# Altium schematic sheet Control_Schem.SchDoc(Control_Schem) (continued)
## Library data of the parts (continued)
D1-D4 = LED_Red: Radial T1 3/4 Infrared LED Infrared LED, 5mm, 1-Element, 950nm; Manufacturer: Osram; Fall Time: 12ns; Forward Current: 100mA; Forward Voltage: 1.7V; Lens Style: Circular, Dome; Max Power Dissipation: 180mW; Number of Circuits: 1; Output Power: 55mW; Power Dissipation: 180W; Reverse Voltage: 5V; Shape: Round; Touchscreen: Infrared (IR); Viewing Angle: 10°; Case/Package: Radial; Category: LEDs; China RoHS: Compliant; Component Kind: Standard; ComponentLink1Description: https://datasheet.octopart.com:443/Q65110A8095-Osram-Opto-datasheet-182349644.pdf?src-supplier=IHS; ComponentLink1URL: https://datasheet.octopart.com:443/Q65110A8095-Osram-Opto-datasheet-182349644.pdf?src-supplier=IHS; ComponentLink2Description: https://datasheet.octopart.com:443/SFH-4545-Osram-Opto-datasheet-142374590.pdf?src-supplier=Osram+Opto+SCT; ComponentLink2URL: https://datasheet.octopart.com:443/SFH-4545-Osram-Opto-datasheet-142374590.pdf?src-supplier=Osram+Opto+SCT; ComponentLink3Description: https://datasheet.octopart.com:443/SFH-4545-Osram-datasheet-68365660.pdf?src-supplier=TME; ComponentLink3URL: https://datasheet.octopart.com:443/SFH-4545-Osram-datasheet-68365660.pdf?src-supplier=TME; ComponentLink4Description: https://datasheet.octopart.com:443/Q65110A8095-Osram-datasheet-13703669.pdf?src-supplier=_legacy+Avnet; ComponentLink4URL: https://datasheet.octopart.com:443/Q65110A8095-Osram-datasheet-13703669.pdf?src-supplier=_legacy+Avnet; ComponentLink5Description: https://datasheet.octopart.com:443/SFH-4545-Osram-Sylvania-datasheet-17020525.pdf?src-supplier=Factory+Futures; ComponentLink5URL: https://datasheet.octopart.com:443/SFH-4545-Osram-Sylvania-datasheet-17020525.pdf?src-supplier=Factory+Futures; Contact Plating: Tin; Depth: 5.7mm; Harmonized Tariff Schedule (HTS) Code: 8541.40.20.00; Height: 7.9mm; Introduction Date: 2009-09-08; Length: 5.5mm; Library Name: Standard.SchLib; Lifecycle Status: Production; Manufacturer Part Number: SFH 4545; Max Operating Temperature: 100°C; Min Operating Temperature: -40°C; Mounting Technology: Through Hole; Number of Elements: 1; Number of LEDs: 1; Orientation: Top View; Packaging: Tape & Reel; Peak Wavelength: 950nm; Pins: 2; Power Rating: 180mW; Pricing: 1000=0.2268, 2000=0.1849, 3000=0.1831, 4000=0.1814 (USD); Radiation Hardening: No; REACH SVHC: Yes; Reverse Voltage (DC): 5V; Rise Time: 12ns; RoHS: Compliant; Schedule B: 8541402000, 8541402000|8541402000|8541402000|8541402000|8541402000; Stock: 100000; Supplier 1: Rutronik; Supplier Part Number 1: IR5241; Test Current: 100mA; Width: 5.5mm
D5 = LED_White: White 1.6 x 0.8 x 0.55 mm 130° Tinted Yellow 180 mcd 3 V LED SMT; Manufacturer: Diodes Inc.; Manufacturer Package Identifier: LTW-C191DS5; Forward Current: 20mA; Forward Voltage: 3.15V; Lens Style: Colored, Rectangular, Tinted; Luminous Intensity: 125.5mcd; Number of Circuits: 1; Power Dissipation: 72mW; Reverse Voltage: 5V; Shape: Rectangular; Test Current: 5mA; Case/Package: 0603; Category: LEDs; China RoHS: Compliant; Color: White; Component Kind: Standard; ComponentLink1Description: https://sigma.octopart.com:443/141906765/technical_drawing/Lite-On-LTW-C191DS5.pdf?src-supplier=IHS; ComponentLink1URL: https://sigma.octopart.com:443/141906765/technical_drawing/Lite-On-LTW-C191DS5.pdf?src-supplier=IHS; ComponentLink2Description: https://datasheet.octopart.com:443/LTW-C191DS5-Lite-On-datasheet-138896769.pdf?src-supplier=Upverter; ComponentLink2URL: https://datasheet.octopart.com:443/LTW-C191DS5-Lite-On-datasheet-138896769.pdf?src-supplier=Upverter; ComponentLink3Description: https://datasheet.octopart.com:443/LTW-C191DS5-Lite-On-datasheet-26684820.pdf?src-supplier=TME; ComponentLink3URL: https://datasheet.octopart.com:443/LTW-C191DS5-Lite-On-datasheet-26684820.pdf?src-supplier=TME; ComponentLink4Description: https://datasheet.octopart.com:443/LTW-C191DS5-Lite-On-datasheet-165573808.pdf?src-supplier=TME; ComponentLink4URL: https://datasheet.octopart.com:443/LTW-C191DS5-Lite-On-datasheet-165573808.pdf?src-supplier=TME; ComponentLink5Description: https://datasheet.octopart.com:443/LTW-C191DS5-Lite-On-datasheet-86397359.pdf?src-supplier=ODG+(Origin+Data+Global); ComponentLink5URL: https://datasheet.octopart.com:443/LTW-C191DS5-Lite-On-datasheet-86397359.pdf?src-supplier=ODG+(Origin+Data+Global); ComponentLink6Description: https://datasheet.octopart.com:443/LTW-C191DS5-Lite-On-datasheet-13698150.pdf?src-supplier=_legacy+Avnet; ComponentLink6URL: https://datasheet.octopart.com:443/LTW-C191DS5-Lite-On-datasheet-13698150.pdf?src-supplier=_legacy+Avnet; ComponentLink7Description: https://datasheet.octopart.com:443/LTW-C191DS5-Lite-On-datasheet-12531532.pdf?src-supplier=Future+Electronics; ComponentLink7URL: https://datasheet.octopart.com:443/LTW-C191DS5-Lite-On-datasheet-12531532.pdf?src-supplier=Future+Electronics; Depth: 800µm; Harmonized Tariff Schedule (HTS) Code: 8541.40.20.00; Height: 550µm; Illumination Color: White; Introduction Date: 2006-05-17; Lead Free: Lead Free; Length: 1.6mm; Lens Color: Yellow; Library Name: Standard.SchLib; Lifecycle Status: Production; Manufacturer Part Number: LTW-C191DS5; Max Operating Temperature: 85°C; Min Operating Temperature: -30°C; Mounting Technology: Surface Mount; Number of Elements: 1; Number of LEDs: 1; Number of Terminals: 2; Pins: 2; Pricing: 5000=0.10228, 10000=0.09997, 20000=0.09766, 40000=0.09536, 80000=0.09305 (USD); Radiation Hardening: No; REACH SVHC: Yes; RoHS: Compliant; Stock: 35000; Supplier 1: Avnet; Supplier Part Number 1: LTW-C191DS5; Terminal Pitch: 1.5mm; Viewing Angle: 130°; Width: 800µm
D6 = LED_Blue: LED blue 0603 wavelength 465~475nm light intensity 15mcd Front Mounting; Manufacturer: Diodes Inc.; Manufacturer Part Number: LTST-C193TBKT-5A; Dominant Wavelength: 475nm; Forward Current: 20mA; Forward Voltage: 2.8V; Lens Color: Clear, Colorless; Lens Style: Clear, Colorless, Diffused, Rectangular; Lens Transparency: Clear; Number of Circuits: 1; Peak Wavelength: 468nm; Power Dissipation: 76mW; Reverse Voltage: 5V; Shape: Rectangular; Test Current: 5mA; Viewing Angle: 130°; Wavelength: 470nm; Case Code (Imperial): 0603; Case Code (Metric): 1608; Case/Package: 0603; Category: LEDs; China RoHS: Compliant; Color: Blue; Component Kind: Standard; ComponentLink1Description: https://datasheet.octopart.com:443/LTST-C193TBKT-5A-Lite-On-datasheet-26684754.pdf?src-supplier=IHS; ComponentLink1URL: https://datasheet.octopart.com:443/LTST-C193TBKT-5A-Lite-On-datasheet-26684754.pdf?src-supplier=IHS; ComponentLink2Description: https://datasheet.octopart.com:443/LTST-C193TBKT-5A-Lite-On-datasheet-12541985.pdf?src-supplier=Upverter; ComponentLink2URL: https://datasheet.octopart.com:443/LTST-C193TBKT-5A-Lite-On-datasheet-12541985.pdf?src-supplier=Upverter; ComponentLink3Description: https://datasheet.octopart.com:443/LTST-C193TBKT-5A-Lite-On-datasheet-165573808.pdf?src-supplier=TME; ComponentLink3URL: https://datasheet.octopart.com:443/LTST-C193TBKT-5A-Lite-On-datasheet-165573808.pdf?src-supplier=TME; ComponentLink4Description: https://datasheet.octopart.com:443/LTST-C193TBKT-5A-Lite-On-datasheet-14174967.pdf?src-supplier=iiiC; ComponentLink4URL: https://datasheet.octopart.com:443/LTST-C193TBKT-5A-Lite-On-datasheet-14174967.pdf?src-supplier=iiiC; Contact Plating: Tin; Depth: 800µm; Height: 350µm; Illumination Color: Blue; Lead Free: Lead Free; Length: 1.6mm; Library Name: Standard.SchLib; Lifecycle Status: Production; Luminous Intensity: 15mcd; Max Operating Temperature: 80°C; Min Operating Temperature: -20°C; Mounting Technology: Surface Mount; Number of Elements: 1; Number of LEDs: 1; Number of Terminals: 2; Pins: 2; Pricing: 5000=0.04509, 10000=0.04407, 20000=0.04305, 40000=0.04204, 80000=0.04102 (USD); Radiation Hardening: No; REACH SVHC: Yes; RoHS: Compliant; Schedule B: 8541402000, 8541402000|8541402000|8541402000|8541402000|8541402000; Stock: 200000; Supplier 1: Avnet; Supplier Part Number 1: LTST-C193TBKT-5A; Terminal Pitch: 1.5mm; Width: 800µm
D7 = LED_Red: Single-color LEDs; LITEON; LTST-C193KRKT-5A; Red; 130 °; 2.3 V; 0603; Manufacturer: Diodes Inc.; Dominant Wavelength: 631nm; Forward Current: 20mA; Forward Voltage: 2.3V; Lens Color: Clear, Colorless; Lens Style: Clear, Colorless, Diffused, Rectangular; Lens Transparency: Clear; Luminous Intensity: 26.05mcd; Number of Circuits: 1; Peak Wavelength: 639nm; Power Dissipation: 50mW; Reverse Voltage: 5V; Shape: Rectangular; Test Current: 5mA; Viewing Angle: 130°; Case Code (Imperial): 0603; Case Code (Metric): 1608; Case/Package: 0603; Category: LEDs; China RoHS: Compliant; Color: Red; Component Kind: Standard; ComponentLink1Description: https://datasheet.octopart.com:443/LTST-C193KRKT-5A-Lite-On-datasheet-141422095.pdf?src-supplier=ODG+(Origin+Data+Global); ComponentLink1URL: https://datasheet.octopart.com:443/LTST-C193KRKT-5A-Lite-On-datasheet-141422095.pdf?src-supplier=ODG+(Origin+Data+Global); ComponentLink2Description: https://datasheet.octopart.com:443/LTST-C193KRKT-5A-Lite-On-datasheet-86397331.pdf?src-supplier=Upverter; ComponentLink2URL: https://datasheet.octopart.com:443/LTST-C193KRKT-5A-Lite-On-datasheet-86397331.pdf?src-supplier=Upverter; ComponentLink3Description: https://datasheet.octopart.com:443/LTST-C193KRKT-5A-Lite-On-datasheet-180605208.pdf?src-supplier=IHS; ComponentLink3URL: https://datasheet.octopart.com:443/LTST-C193KRKT-5A-Lite-On-datasheet-180605208.pdf?src-supplier=IHS; ComponentLink4Description: https://datasheet.octopart.com:443/LTST-C193KRKT-5A-Lite-On-datasheet-26684753.pdf?src-supplier=TME; ComponentLink4URL: https://datasheet.octopart.com:443/LTST-C193KRKT-5A-Lite-On-datasheet-26684753.pdf?src-supplier=TME; ComponentLink5Description: https://datasheet.octopart.com:443/LTST-C193KRKT-5A-Lite-On-datasheet-165573808.pdf?src-supplier=TME; ComponentLink5URL: https://datasheet.octopart.com:443/LTST-C193KRKT-5A-Lite-On-datasheet-165573808.pdf?src-supplier=TME; ComponentLink6Description: https://datasheet.octopart.com:443/LTST-C193KRKT-5A-Lite-On-datasheet-13688521.pdf?src-supplier=_legacy+Avnet; ComponentLink6URL: https://datasheet.octopart.com:443/LTST-C193KRKT-5A-Lite-On-datasheet-13688521.pdf?src-supplier=_legacy+Avnet; ComponentLink7Description: https://datasheet.octopart.com:443/LTST-C193KRKT-5A-Lite-On-datasheet-137080926.pdf?src-supplier=X-ON; ComponentLink7URL: https://datasheet.octopart.com:443/LTST-C193KRKT-5A-Lite-On-datasheet-137080926.pdf?src-supplier=X-ON; ComponentLink8Description: https://datasheet.octopart.com:443/LTST-C193KRKT-5A-Lite-On-datasheet-11563339.pdf?src-supplier=Arrow+Electronics; ComponentLink8URL: https://datasheet.octopart.com:443/LTST-C193KRKT-5A-Lite-On-datasheet-11563339.pdf?src-supplier=Arrow+Electronics; ComponentLink9Description: https://datasheet.octopart.com:443/LTST-C193KRKT-5A-Lite-On-datasheet-14174970.pdf?src-supplier=iiiC; ComponentLink9URL: https://datasheet.octopart.com:443/LTST-C193KRKT-5A-Lite-On-datasheet-14174970.pdf?src-supplier=iiiC; ComponentLink10Description: https://datasheet.octopart.com:443/LTST-C193KRKT-5A-Lite-On-datasheet-25360257.pdf?src-supplier=Future+Electronics; ComponentLink10URL: https://datasheet.octopart.com:443/LTST-C193KRKT-5A-Lite-On-datasheet-25360257.pdf?src-supplier=Future+Electronics; Country of Origin: Mainland China, Thailand; Depth: 800µm; Export Control Classification Number (ECCN) Code: EAR99; Harmonized Tariff Schedule (HTS) Code: 8541.40.20.00; Height: 350µm; Illumination Color: Red; Introduction Date: 2008-09-01; Lead Free: Lead Free; Length: 1.6mm; Library Name: Standard.SchLib; Lifecycle Status: Production; Manufacturer Part Number: LTST-C193KRKT-5A; Max Operating Temperature: 85°C; Min Operating Temperature: -30°C; Mounting Technology: Surface Mount; Number of Elements: 1; Number of LEDs: 1; Number of Terminals: 2; Pins: 2; Pricing: 5000=0.03977, 10000=0.03887, 20000=0.03797, 40000=0.03708, 80000=0.03618 (USD); Radiation Hardening: No; REACH SVHC: Yes; RoHS: Compliant; Schedule B: 8541402000, 8541402000|8541402000|8541402000|8541402000|8541402000; Stock: 250000; Supplier 1: Avnet; Supplier Part Number 1: LTST-C193KRKT-5A; Terminal Pitch: 1.5mm; Wavelength: 631nm; Width: 800µm
D8 D9 = Zenner: ESD9X5.0ST5G Series 6.2 V 65 pF TVS Diode for ESD Protection - SOD-923; Manufacturer: onsemi; Manufacturer Lifecycle Status: ACTIVE; Breakdown Voltage: 6.2V; Clamping Voltage: 12.3V; Direction: Unidirectional; Element Configuration: Single; ESD Protection: Yes; Forward Voltage: 1.1V; Leakage Current: 1µA; Max Junction Temperature (Tj): 150°C; Max Power Dissipation: 150mW; Max Surge Current: 8.7A; Number of Channels: 1; Operating Supply Voltage: 5V; Peak Pulse Power: 107W; Power Line Protection: No; Test Current: 1mA; Case/Package: SOD-923-2; Category: TVS Diodes; China RoHS: Compliant; Component Kind: Standard; ComponentLink1Description: https://datasheet.octopart.com:443/ESD9X5.0ST5G-ON-Semiconductor-datasheet-8330357.pdf?src-supplier=Upverter; ComponentLink1URL: https://datasheet.octopart.com:443/ESD9X5.0ST5G-ON-Semiconductor-datasheet-8330357.pdf?src-supplier=Upverter; ComponentLink2Description: https://datasheet.octopart.com:443/ESD9X5.0ST5G-onsemi-datasheet-168568609.pdf?src-supplier=Upverter; ComponentLink2URL: https://datasheet.octopart.com:443/ESD9X5.0ST5G-onsemi-datasheet-168568609.pdf?src-supplier=Upverter; ComponentLink3Description: https://datasheet.octopart.com:443/ESD9X5.0ST5G-ON-Semiconductor-datasheet-139009868.pdf?src-supplier=Electro+Sonic; ComponentLink3URL: https://datasheet.octopart.com:443/ESD9X5.0ST5G-ON-Semiconductor-datasheet-139009868.pdf?src-supplier=Electro+Sonic; ComponentLink4Description: https://datasheet.octopart.com:443/ESD9X5.0ST5G-onsemi-datasheet-180958921.pdf?src-supplier=IHS; ComponentLink4URL: https://datasheet.octopart.com:443/ESD9X5.0ST5G-onsemi-datasheet-180958921.pdf?src-supplier=IHS; ComponentLink5Description: https://datasheet.octopart.com:443/ESD9X5.0ST5G-ON-Semiconductor-datasheet-12513463.pdf?src-supplier=Newark; ComponentLink5URL: https://datasheet.octopart.com:443/ESD9X5.0ST5G-ON-Semiconductor-datasheet-12513463.pdf?src-supplier=Newark; ComponentLink6Description: https://datasheet.octopart.com:443/ESD9X5.0ST5G-onsemi-datasheet-189128587.pdf?src-supplier=Master+Electronics; ComponentLink6URL: https://datasheet.octopart.com:443/ESD9X5.0ST5G-onsemi-datasheet-189128587.pdf?src-supplier=Master+Electronics; ComponentLink7Description: https://datasheet.octopart.com:443/ESD9X5.0ST5G-onsemi-datasheet-164667579.pdf?src-supplier=Newchip+Electronics+Limited; ComponentLink7URL: https://datasheet.octopart.com:443/ESD9X5.0ST5G-onsemi-datasheet-164667579.pdf?src-supplier=Newchip+Electronics+Limited; ComponentLink8Description: https://datasheet.octopart.com:443/ESD9X5.0ST5G-ON-Semiconductor-datasheet-14047635.pdf?src-supplier=iiiC; ComponentLink8URL: https://datasheet.octopart.com:443/ESD9X5.0ST5G-ON-Semiconductor-datasheet-14047635.pdf?src-supplier=iiiC; ComponentLink9Description: http://sigma.octopart.com:80/15540786/rohs_certificate/ON-Semiconductor-ESD9X5.0ST5G.pdf?src-supplier=Compliance+Statements; ComponentLink9URL: http://sigma.octopart.com:80/15540786/rohs_certificate/ON-Semiconductor-ESD9X5.0ST5G.pdf?src-supplier=Compliance+Statements; ComponentLink10Description: http://sigma.octopart.com:80/15676178/materials_sheet/ON-Semiconductor-ESD9X5.0ST5G.csv?src-supplier=Compliance+Statements; ComponentLink10URL: http://sigma.octopart.com:80/15676178/materials_sheet/ON-Semiconductor-ESD9X5.0ST5G.csv?src-supplier=Compliance+Statements; ComponentLink11Description: http://sigma.octopart.com:80/150607609/rohs_statement/ON-Semiconductor-ESD9X5.0ST5G.pdf?src-supplier=Compliance+Statements; ComponentLink11URL: http://sigma.octopart.com:80/150607609/rohs_statement/ON-Semiconductor-ESD9X5.0ST5G.pdf?src-supplier=Compliance+Statements; ComponentLink12Description: http://sigma.octopart.com:80/reach_statement/ON-Semiconductor-company-79.pdf?src-supplier=Compliance+Statements; ComponentLink12URL: http://sigma.octopart.com:80/reach_statement/ON-Semiconductor-company-79.pdf?src-supplier=Compliance+Statements; ComponentLink13Description: https://octopart.com:443/opatz8j6/c1?t=U4xq248CjZk49aqVlr5k0EZ1S20O7hXZC5x3rHWSH-sYxZ_igJwI8JXMjxjum5nk7b2LPFvSUdjhtWeLCCyF45HKJ3gQrOw2ICCLdwIt4F_CeAv9k9h4bPg01xsOqGsl4_WPHk2-sHZisKbPVHvESszEH42MI_EGcnWZn37s8EDrb9R9sRHl5QrF73OS571ory3wTq49jEdwCvmsvOyGlnMZqdznSgUnAulqt8UeVTtCWi1h0LjaV3_jenB72zXObhJcrUkKGX87J72uvMIaqlf2gdrzqerpU4qgDLbtRMl999sH-387FE5OvjKwPt4qFBwoXQia9kWdxdoPHguyJMxxDQ6CZJ78I1Jz7wf_&src-supplier=Compliance+Statements; ComponentLink13URL: https://octopart.com:443/opatz8j6/c1?t=U4xq248CjZk49aqVlr5k0EZ1S20O7hXZC5x3rHWSH-sYxZ_igJwI8JXMjxjum5nk7b2LPFvSUdjhtWeLCCyF45HKJ3gQrOw2ICCLdwIt4F_CeAv9k9h4bPg01xsOqGsl4_WPHk2-sHZisKbPVHvESszEH42MI_EGcnWZn37s8EDrb9R9sRHl5QrF73OS571ory3wTq49jEdwCvmsvOyGlnMZqdznSgUnAulqt8UeVTtCWi1h0LjaV3_jenB72zXObhJcrUkKGX87J72uvMIaqlf2gdrzqerpU4qgDLbtRMl999sH-387FE5OvjKwPt4qFBwoXQia9kWdxdoPHguyJMxxDQ6CZJ78I1Jz7wf_&src-supplier=Compliance+Statements; ComponentLink14Description: https://octopart.com:443/opatz8j6/c1?t=3pWjA3sury-vXDZ6jn5EtAlCMoxYtX1AYxU0u-L05MRKzzY3i90hWYZqBJ7nD0FpcxQU6NVvWSfrj8spDvkDVcv6hBGs2FKejoF387FKwRHUXxrKuAp20zxQf1xviP5DOSGH0oDp6nciOnSgABqDgBRfE1IdXVb1HgMibrXHg5ILIw0i4bTNvinhI9nbqtNHG7c2z1LCxAmzkrm1UggRYQnaU9WfKM8lDyf7V15dE3D-xK99Oqq1XK1trMaZ5tCK2HDTe7-XsgP0FTthRAXuAn3xjXwE5sHZ4Tfbg-hc08R_QhUItco4PPzxqp_Ni38_sf5Aw8nlbFeh5fp6Pc1W3BfPLWy2YwzTcFmoPFUupg&src-supplier=Compliance+Statements; ComponentLink14URL: https://octopart.com:443/opatz8j6/c1?t=3pWjA3sury-vXDZ6jn5EtAlCMoxYtX1AYxU0u-L05MRKzzY3i90hWYZqBJ7nD0FpcxQU6NVvWSfrj8spDvkDVcv6hBGs2FKejoF387FKwRHUXxrKuAp20zxQf1xviP5DOSGH0oDp6nciOnSgABqDgBRfE1IdXVb1HgMibrXHg5ILIw0i4bTNvinhI9nbqtNHG7c2z1LCxAmzkrm1UggRYQnaU9WfKM8lDyf7V15dE3D-xK99Oqq1XK1trMaZ5tCK2HDTe7-XsgP0FTthRAXuAn3xjXwE5sHZ4Tfbg-hc08R_QhUItco4PPzxqp_Ni38_sf5Aw8nlbFeh5fp6Pc1W3BfPLWy2YwzTcFmoPFUupg&src-supplier=Compliance+Statements; Composition: Zener; Contact Plating: Tin; Depth: 600µm; Halogen Free: Halogen Free; Height: 400µm; Lead Free: Lead Free; Length: 800µm; Library Name: Standard.SchLib; Lifecycle Status: Production; Manufacturer Part Number: ESD9X5.0ST5G; Max Breakdown Voltage: 6.2V; Max Operating Temperature: 150°C; Max Reverse Leakage Current: 1µA; Min Breakdown Voltage: 6.2V; Min Operating Temperature: -55°C; Number of Circuits: 1; Number of Elements: 1; Number of Lines: 1; Number of Terminals: 2; Number of Unidirectional Channels: 1; Packaging: Tape and Reel; Peak Pulse Current: 8.7A; Peak Reverse Current: 1µA; Pins: 2; Polarity: Unidirectional; Pricing: 8000=0.0268, 24000=0.0262, 32000=0.0261, 80000=0.0255, 120000=0.025 (USD); Radiation Hardening: No; REACH SVHC: Yes; Reverse Breakdown Voltage: 6.2V; Reverse Standoff Voltage: 5V; RoHS: Compliant; Schedule B: 8536300000, 8536300000|8536300000|8536300000|8536300000|8536300000|8536300000|8536300000|8536300000|8536300000|8536300000|8536300000|8536300000|8536300000|8536300000|8536300000|8536300000|8536300000|8536300000|8536300000|8536300000|8536300000|8536300000|8536300000|85; Stock: 200000; Supplier 1: Future Electronics; Supplier Part Number 1: ESD9X5.0ST5G; Termination: SMD/SMT; Value: 65pF; Width: 650µm; Working Voltage: 5V
J2 J3 = 6POS: Connector Header, SR Series, 6 Position, 1.00mm, 50V, Surface Mount, Tin, Natural; Manufacturer: JST; Manufacturer Part Number: BM06B-SRSS-TB(LF)(SN); Connector Type: Male Pin; Contact Resistance: 40mΩ; Current Rating: 1A; Dielectric Material: Polyamide; Gender: Male; Insulation Resistance: 100MΩ; Number of Contacts: 6; Pitch: 1mm; Shape: Straight; Category: Headers and Wire Housings; China RoHS: Compliant; Color: Natural; Component Kind: Standard; ComponentLink1Description: https://datasheet.octopart.com:443/BM06B-SRSS-TB%28LF%29%28SN%29-JST-datasheet-120967.pdf?src-supplier=Upverter; ComponentLink1URL: https://datasheet.octopart.com:443/BM06B-SRSS-TB%28LF%29%28SN%29-JST-datasheet-120967.pdf?src-supplier=Upverter; ComponentLink2Description: https://datasheet.octopart.com:443/BM06B-SRSS-TB%28LF%29%28SN%29-JST-datasheet-152738602.pdf?src-supplier=Upverter; ComponentLink2URL: https://datasheet.octopart.com:443/BM06B-SRSS-TB%28LF%29%28SN%29-JST-datasheet-152738602.pdf?src-supplier=Upverter; ComponentLink3Description: https://datasheet.octopart.com:443/BM06B-SRSS-TB%28LF%29%28SN%29-JST-datasheet-12489618.pdf?src-supplier=Component+Distributors+Inc.; ComponentLink3URL: https://datasheet.octopart.com:443/BM06B-SRSS-TB%28LF%29%28SN%29-JST-datasheet-12489618.pdf?src-supplier=Component+Distributors+Inc.; ComponentLink4Description: https://datasheet.octopart.com:443/BM06B-SRSS-TB%28LF%29%28SN%29-JST-datasheet-65849987.pdf?src-supplier=element14+APAC; ComponentLink4URL: https://datasheet.octopart.com:443/BM06B-SRSS-TB%28LF%29%28SN%29-JST-datasheet-65849987.pdf?src-supplier=element14+APAC; ComponentLink5Description: https://datasheet.octopart.com:443/BM06B-SRSS-TB%28LF%29%28SN%29-JST-datasheet-12513377.pdf?src-supplier=Factory+Futures; ComponentLink5URL: https://datasheet.octopart.com:443/BM06B-SRSS-TB%28LF%29%28SN%29-JST-datasheet-12513377.pdf?src-supplier=Factory+Futures; ComponentLink6Description: https://datasheet.octopart.com:443/BM06B-SRSS-TB%28LF%29%28SN%29-JST-datasheet-5322443.pdf?src-supplier=Newark; ComponentLink6URL: https://datasheet.octopart.com:443/BM06B-SRSS-TB%28LF%29%28SN%29-JST-datasheet-5322443.pdf?src-supplier=Newark; ComponentLink7Description: https://datasheet.octopart.com:443/BM06B-SRSS-TB-%28LF%29%28SN%29-JST-datasheet-44103209.pdf?src-supplier=TME; ComponentLink7URL: https://datasheet.octopart.com:443/BM06B-SRSS-TB-%28LF%29%28SN%29-JST-datasheet-44103209.pdf?src-supplier=TME; ComponentLink8Description: https://datasheet.octopart.com:443/BM06B-SRSS-TB%28LF%29%28SN%29-JST-datasheet-8330955.pdf?src-supplier=Farnell; ComponentLink8URL: https://datasheet.octopart.com:443/BM06B-SRSS-TB%28LF%29%28SN%29-JST-datasheet-8330955.pdf?src-supplier=Farnell; Contact Material: Copper, Tin; Contact Plating: Tin; Country of Origin: Mainland China; Export Control Classification Number (ECCN) Code: EAR99; Flammability Rating: UL94 V-0; Harmonized Tariff Schedule (HTS) Code: 8536.69.40.40; Height: 4.25mm; Housing Color: Natural; Housing Material: Nylon; Introduction Date: 2009-02-11; Lead Free: Lead Free; Lead Pitch: 1mm; Length: 8mm; Library Name: Connectors.SchLib; Lifecycle Status: Production; Material: Polyamide; Max Operating Temperature: 85°C; Min Operating Temperature: -25°C; Mounting Technology: Surface Mount; Number of Poles: 6; Number of Positions: 6; Number of Rows: 1; Packaging: Cut Tape; Pins: 6; Pricing: 1500=0.35, 3000=0.345, 4500=0.34 (USD); REACH SVHC: Yes; RoHS: Compliant; Schedule B: 8536694040; Stock: 27000; Supplier 1: Future Electronics; Supplier Part Number 1: BM06B-SRSS-TB(LF)(SN); Terminal Pitch: 1mm; Termination: Solder; Voltage Rating: 50V; Voltage Rating (AC): 50V; Width: 2.9mm
J4 = 6POS: Connector Header, SR Series, 6 Position, 1.00mm, 50V, Surface Mount, Tin, Natural; Library Name: Connectors.SchLib
Q1 Q2 Q5 Q6 = NPN_PHOTOTRANSISTOR: TEFT4300 Series 925 nm ±60° 70 V 50 mA Silicon NPN Phototransistor - T-5; Manufacturer: Vishay; Collector Emitter Breakdown Voltage: 70V; Collector Emitter Saturation Voltage: 300mV; Dark Current: 200nA; Fall Time: 2.3µs; Lens Style: Domed; Max Collector Current: 50mA; Max Power Dissipation: 185mW; Number of Channels: 1; Peak Wavelength: 925nm; Power Consumption: 100mW; Shape: Round; Viewing Angle: 30°; Case/Package: T; Category: Photoelectric Sensors; Collector Emitter Voltage (VCEO): 70V; Component Kind: Standard; ComponentLink1Description: https://datasheet.octopart.com:443/TEFT4300-Vishay-datasheet-181475792.pdf?src-supplier=IHS; ComponentLink1URL: https://datasheet.octopart.com:443/TEFT4300-Vishay-datasheet-181475792.pdf?src-supplier=IHS; ComponentLink2Description: https://datasheet.octopart.com:443/TEFT4300-Vishay-datasheet-181483772.pdf?src-supplier=IHS; ComponentLink2URL: https://datasheet.octopart.com:443/TEFT4300-Vishay-datasheet-181483772.pdf?src-supplier=IHS; ComponentLink3Description: https://datasheet.octopart.com:443/TEFT4300-Vishay-datasheet-12551779.pdf?src-supplier=Farnell; ComponentLink3URL: https://datasheet.octopart.com:443/TEFT4300-Vishay-datasheet-12551779.pdf?src-supplier=Farnell; ComponentLink4Description: https://datasheet.octopart.com:443/TEFT4300-Vishay-datasheet-8540529.pdf?src-supplier=Farnell; ComponentLink4URL: https://datasheet.octopart.com:443/TEFT4300-Vishay-datasheet-8540529.pdf?src-supplier=Farnell; ComponentLink5Description: https://datasheet.octopart.com:443/TEFT4300-Vishay-datasheet-62319322.pdf?src-supplier=element14+APAC; ComponentLink5URL: https://datasheet.octopart.com:443/TEFT4300-Vishay-datasheet-62319322.pdf?src-supplier=element14+APAC; ComponentLink6Description: https://datasheet.octopart.com:443/TEFT4300-Vishay-Semiconductor-datasheet-41195801.pdf?src-supplier=element14+APAC; ComponentLink6URL: https://datasheet.octopart.com:443/TEFT4300-Vishay-Semiconductor-datasheet-41195801.pdf?src-supplier=element14+APAC; ComponentLink7Description: https://datasheet.octopart.com:443/TEFT4300..-Vishay-datasheet-78863672.pdf?src-supplier=element14+APAC; ComponentLink7URL: https://datasheet.octopart.com:443/TEFT4300..-Vishay-datasheet-78863672.pdf?src-supplier=element14+APAC; ComponentLink8Description: https://datasheet.octopart.com:443/TEFT4300-Vishay-datasheet-68328189.pdf?src-supplier=TME; ComponentLink8URL: https://datasheet.octopart.com:443/TEFT4300-Vishay-datasheet-68328189.pdf?src-supplier=TME; ComponentLink9Description: https://datasheet.octopart.com:443/TEFT4300-Vishay-datasheet-33014373.pdf?src-supplier=Newark; ComponentLink9URL: https://datasheet.octopart.com:443/TEFT4300-Vishay-datasheet-33014373.pdf?src-supplier=Newark; ComponentLink10Description: https://datasheet.octopart.com:443/TEFT4300-Vishay-datasheet-63338.pdf?src-supplier=Newark; ComponentLink10URL: https://datasheet.octopart.com:443/TEFT4300-Vishay-datasheet-63338.pdf?src-supplier=Newark; ComponentLink11Description: http://sigma.octopart.com:80/rohs_statement/Vishay-company-15.pdf?src-supplier=Compliance+Statements; ComponentLink11URL: http://sigma.octopart.com:80/rohs_statement/Vishay-company-15.pdf?src-supplier=Compliance+Statements; Harmonized Tariff Schedule (HTS) Code: 8541.40.70.80; Height: 4.5mm; Introduction Date: 1995-08-01; Lead Free: Lead Free; Length: 3.2mm; Library Name: Standard.SchLib; Lifecycle Status: Production; Manufacturer Part Number: TEFT4300; Max Operating Temperature: 100°C; Min Operating Temperature: -40°C; Mounting Technology: Through Hole; Number of Circuits: 1; Number of Elements: 1; Orientation: Top View; Pins: 2; Polarity: NPN; Power Dissipation: 100mW; Pricing: 5000=0.17962, 10000=0.17625, 20000=0.17407, 40000=0.17091, 80000=0.16588 (USD); Radiation Hardening: No; REACH SVHC: Yes; RoHS: Compliant; Schedule B: 8541407080, 8541407080|8541407080|8541407080|8541407080|8541407080; Stock: 5000; Supplier: Avnet; Supplier 1: Avnet; Supplier Part Number: TEFT4300; Supplier Part Number 1: TEFT4300; Wavelength: 925nm; Width: 3.9mm
Q3 Q4 Q7 Q8 = NMOS: Transistor MOSFET N-CH 60V 0.32A Automotive 3-Pin SC-70 T/R; Manufacturer: Nexperia; Manufacturer Lifecycle Status: RELEASED FOR SUPPLY; Continuous Drain Current (ID): 320mA; Drain to Source Resistance: 1.6Ω; Max Power Dissipation: 310mW; Number of Elements: 1; Category: MOSFETs; China RoHS: Compliant; Component Kind: Standard; ComponentLink1Description: https://datasheet.octopart.com:443/BSS138PW%2C115-Nexperia-datasheet-87581096.pdf?src-supplier=Newark; ComponentLink1URL: https://datasheet.octopart.com:443/BSS138PW%2C115-Nexperia-datasheet-87581096.pdf?src-supplier=Newark; ComponentLink2Description: https://datasheet.octopart.com:443/BSS138PW%2C115-Nexperia-datasheet-10542180.pdf?src-supplier=IHS; ComponentLink2URL: https://datasheet.octopart.com:443/BSS138PW%2C115-Nexperia-datasheet-10542180.pdf?src-supplier=IHS; ComponentLink3Description: https://datasheet.octopart.com:443/BSS138PW%2C115-Nexperia-datasheet-180923270.pdf?src-supplier=Farnell; ComponentLink3URL: https://datasheet.octopart.com:443/BSS138PW%2C115-Nexperia-datasheet-180923270.pdf?src-supplier=Farnell; ComponentLink4Description: http://sigma.octopart.com:80/reach_statement/NXP-Semiconductors-company-13.pdf?src-supplier=Compliance+Statements; ComponentLink4URL: http://sigma.octopart.com:80/reach_statement/NXP-Semiconductors-company-13.pdf?src-supplier=Compliance+Statements; ComponentLink5Description: http://sigma.octopart.com:80/rohs_statement/NXP-Semiconductors-company-12.pdf?src-supplier=Compliance+Statements; ComponentLink5URL: http://sigma.octopart.com:80/rohs_statement/NXP-Semiconductors-company-12.pdf?src-supplier=Compliance+Statements; Contact Plating: Tin; Country of Origin: Malaysia; Export Control Classification Number (ECCN) Code: EAR99; Introduction Date: 2010-11-11; Library Name: Standard.SchLib; Lifecycle Status: Production; Manufacturer Part Number: BSS138PW,115; Max Operating Temperature: 150°C; Min Breakdown Voltage: 60V; Number of Terminals: 3; Packaging: Tape & Reel; Pins: 3; Pricing: 5=0.35, 10=0.217, 25=0.189, 50=0.161, 100=0.133 (USD); Ratings: AEC-Q101; REACH SVHC: Yes; RoHS: Compliant; Schedule B: 8541210080, 8541210080|8541210080, 8541210080|8541210080|8541210080, 8541210080|8541210080|8541210080|8541210080; Stock: 868; Supplier: Newark; Supplier 1: Newark; Supplier Part Number: 58T1369; Supplier Part Number 1: BSS138PW,115
R1 R2 R14 R15 = RES: 100mW Thick Film Resistors 75V ±5% ±200ppm/℃ 3Ω 0603 Chip Resistor - Surface Mount ROHS; Manufacturer: Yageo Group; Manufacturer Part Number: RC0603JR-073RL; Temperature Coefficient: 200ppm/°C; Voltage Rating: 50V; Case Code (Imperial): 0603; Case/Package: 0603; Category: Chip SMD Resistors; China RoHS: Non-Compliant; Component Kind: Standard; ComponentLink1Description: https://datasheet.octopart.com:443/RC0603JR-073RL-Yageo-datasheet-175887523.pdf?src-supplier=IHS; ComponentLink1URL: https://datasheet.octopart.com:443/RC0603JR-073RL-Yageo-datasheet-175887523.pdf?src-supplier=IHS; ComponentLink2Description: https://datasheet.octopart.com:443/RC0603JR-073RL-Yageo-Group-datasheet-176638170.pdf?src-supplier=Future+Electronics; ComponentLink2URL: https://datasheet.octopart.com:443/RC0603JR-073RL-Yageo-Group-datasheet-176638170.pdf?src-supplier=Future+Electronics; ComponentLink3Description: https://datasheet.octopart.com:443/RC0603JR-073RL-Yageo-datasheet-164759695.pdf?src-supplier=Future+Electronics; ComponentLink3URL: https://datasheet.octopart.com:443/RC0603JR-073RL-Yageo-datasheet-164759695.pdf?src-supplier=Future+Electronics; ComponentLink4Description: https://datasheet.octopart.com:443/RC0603JR-073RL-Yageo-datasheet-137700087.pdf?src-supplier=Future+Electronics; ComponentLink4URL: https://datasheet.octopart.com:443/RC0603JR-073RL-Yageo-datasheet-137700087.pdf?src-supplier=Future+Electronics; ComponentLink5Description: https://datasheet.octopart.com:443/RC0603JR-073RL-Yageo-datasheet-15599030.pdf?src-supplier=Future+Electronics; ComponentLink5URL: https://datasheet.octopart.com:443/RC0603JR-073RL-Yageo-datasheet-15599030.pdf?src-supplier=Future+Electronics; ComponentLink6Description: https://datasheet.octopart.com:443/RC0603JR-073RL-Yageo-datasheet-12596169.pdf?src-supplier=Future+Electronics; ComponentLink6URL: https://datasheet.octopart.com:443/RC0603JR-073RL-Yageo-datasheet-12596169.pdf?src-supplier=Future+Electronics; ComponentLink7Description: https://datasheet.octopart.com:443/RC0603JR-073RL-Yageo-datasheet-8328172.pdf?src-supplier=TME; ComponentLink7URL: https://datasheet.octopart.com:443/RC0603JR-073RL-Yageo-datasheet-8328172.pdf?src-supplier=TME; ComponentLink8Description: https://datasheet.octopart.com/RC0603JR-073RL-Yageo-datasheet-73766026.pdf; ComponentLink8URL: https://datasheet.octopart.com/RC0603JR-073RL-Yageo-datasheet-73766026.pdf; ComponentLink9Description: https://datasheet.octopart.com:443/RC0603JR-073RL-Yageo-datasheet-118562.pdf?src-supplier=DigiKey; ComponentLink9URL: https://datasheet.octopart.com:443/RC0603JR-073RL-Yageo-datasheet-118562.pdf?src-supplier=DigiKey; ComponentLink10Description: http://sigma.octopart.com:80/conflict_mineral_statement/Yageo-company-3.pdf?src-supplier=Compliance+Statements; ComponentLink10URL: http://sigma.octopart.com:80/conflict_mineral_statement/Yageo-company-3.pdf?src-supplier=Compliance+Statements; ComponentLink11Description: http://sigma.octopart.com:80/rohs_statement/Yageo-company-2.pdf?src-supplier=Compliance+Statements; ComponentLink11URL: http://sigma.octopart.com:80/rohs_statement/Yageo-company-2.pdf?src-supplier=Compliance+Statements; Composition: Thick Film; Contact Plating: Lead, Tin; Country of Origin: Mainland China, Taiwan; Depth: 800µm; Export Control Classification Number (ECCN) Code: EAR99; Features: Moisture Resistant; Harmonized Tariff Schedule (HTS) Code: 8533.21.00.30; Height: 450µm; Introduction Date: 2008-07-18; Lead Free: Lead Free; Length: 1.6mm; Library Name: Standard.SchLib; Lifecycle Status: Production; Max Operating Temperature: 155°C; Max Power Dissipation: 100mW; Military Standard: Not; Min Operating Temperature: -55°C; Mounting Technology: Surface Mount; Number of Terminals: 2; Number of Terminations: 2; Power Rating: 100mW; Pricing: 1=0.101, 25=0.011, 50=0.011, 100=0.010 (USD); Radiation Hardening: No; REACH SVHC: Yes; RoHS: Compliant; Schedule B: 8533210045, 8533210045|8533210045|8533210045|8533210045|8533210045; Stock: 51377; Supplier 1: Newark; Supplier Part Number 1: RC0603JR-073RL; Termination: SMD/SMT; Tolerance: 5%; Value: 3R; Voltage Rating (DC): 50V; Width: 800µm; Working Voltage: 75V
R3 R4 R13 R39-R42 = RES: 100mW Thick Film Resistors ±100ppm/℃ ±1% 10kΩ 0603 Chip Resistor - Surface Mount ROHS; Manufacturer: Yageo Group; Manufacturer Part Number: RC0603FR-0710KL; Temperature Coefficient: 100ppm/°C; Voltage Rating: 75V; Voltage Rating (DC): 50V; Case Code (Imperial): 0603; Case Code (Metric): 0603; Case/Package: 0603; Category: Chip SMD Resistors; China RoHS: Non-Compliant; Component Kind: Standard; ComponentLink1Description: https://datasheet.octopart.com:443/RC0603FR-0710KL-Yageo-datasheet-175887523.pdf?src-supplier=IHS; ComponentLink1URL: https://datasheet.octopart.com:443/RC0603FR-0710KL-Yageo-datasheet-175887523.pdf?src-supplier=IHS; ComponentLink2Description: https://datasheet.octopart.com:443/RC0603FR-0710KL-Yageo-datasheet-103092701.pdf?src-supplier=Upverter; ComponentLink2URL: https://datasheet.octopart.com:443/RC0603FR-0710KL-Yageo-datasheet-103092701.pdf?src-supplier=Upverter; ComponentLink3Description: https://datasheet.octopart.com:443/RC0603FR-0710KL-Yageo-datasheet-98239111.pdf?src-supplier=Upverter; ComponentLink3URL: https://datasheet.octopart.com:443/RC0603FR-0710KL-Yageo-datasheet-98239111.pdf?src-supplier=Upverter; ComponentLink4Description: https://datasheet.octopart.com:443/RC0603FR-0710KL-Yageo-datasheet-141265859.pdf?src-supplier=Upverter; ComponentLink4URL: https://datasheet.octopart.com:443/RC0603FR-0710KL-Yageo-datasheet-141265859.pdf?src-supplier=Upverter; ComponentLink5Description: https://sigma.octopart.com:443/138820526/technical_drawing/Yageo-RC0603FR-0710KL.pdf?src-supplier=Upverter; ComponentLink5URL: https://sigma.octopart.com:443/138820526/technical_drawing/Yageo-RC0603FR-0710KL.pdf?src-supplier=Upverter; ComponentLink6Description: https://datasheet.octopart.com:443/RC0603FR-0710KL-Yageo-datasheet-8328172.pdf?src-supplier=Farnell; ComponentLink6URL: https://datasheet.octopart.com:443/RC0603FR-0710KL-Yageo-datasheet-8328172.pdf?src-supplier=Farnell; ComponentLink7Description: https://datasheet.octopart.com:443/RC0603FR-0710KL-Yageo-datasheet-13691069.pdf?src-supplier=Farnell; ComponentLink7URL: https://datasheet.octopart.com:443/RC0603FR-0710KL-Yageo-datasheet-13691069.pdf?src-supplier=Farnell; ComponentLink8Description: https://datasheet.octopart.com:443/RC0603FR-0710KL-Yageo-datasheet-62067350.pdf?src-supplier=element14+APAC; ComponentLink8URL: https://datasheet.octopart.com:443/RC0603FR-0710KL-Yageo-datasheet-62067350.pdf?src-supplier=element14+APAC; ComponentLink9Description: https://datasheet.octopart.com:443/RC0603FR-0710KL-Yageo-datasheet-17842380.pdf?src-supplier=element14+APAC; ComponentLink9URL: https://datasheet.octopart.com:443/RC0603FR-0710KL-Yageo-datasheet-17842380.pdf?src-supplier=element14+APAC; ComponentLink10Description: https://datasheet.octopart.com:443/RC0603FR-0710KL-Yageo-datasheet-15599030.pdf?src-supplier=Future+Electronics; ComponentLink10URL: https://datasheet.octopart.com:443/RC0603FR-0710KL-Yageo-datasheet-15599030.pdf?src-supplier=Future+Electronics; ComponentLink11Description: https://datasheet.octopart.com:443/RC0603FR-0710KL-Yageo-Group-datasheet-176638170.pdf?src-supplier=Future+Electronics; ComponentLink11URL: https://datasheet.octopart.com:443/RC0603FR-0710KL-Yageo-Group-datasheet-176638170.pdf?src-supplier=Future+Electronics; ComponentLink12Description: https://datasheet.octopart.com:443/RC0603FR-0710KL-Yageo-datasheet-164759695.pdf?src-supplier=Future+Electronics; ComponentLink12URL: https://datasheet.octopart.com:443/RC0603FR-0710KL-Yageo-datasheet-164759695.pdf?src-supplier=Future+Electronics; ComponentLink13Description: https://datasheet.octopart.com:443/RC0603FR-0710KL-Yageo-datasheet-127823281.pdf?src-supplier=Future+Electronics; ComponentLink13URL: https://datasheet.octopart.com:443/RC0603FR-0710KL-Yageo-datasheet-127823281.pdf?src-supplier=Future+Electronics; ComponentLink14Description: https://datasheet.octopart.com:443/RC0603FR-0710KL-Yageo-datasheet-137700087.pdf?src-supplier=Future+Electronics; ComponentLink14URL: https://datasheet.octopart.com:443/RC0603FR-0710KL-Yageo-datasheet-137700087.pdf?src-supplier=Future+Electronics; ComponentLink15Description: https://datasheet.octopart.com:443/RC0603FR-0710KL-Yageo-datasheet-27584510.pdf?src-supplier=Future+Electronics; ComponentLink15URL: https://datasheet.octopart.com:443/RC0603FR-0710KL-Yageo-datasheet-27584510.pdf?src-supplier=Future+Electronics; ComponentLink16Description: https://datasheet.octopart.com:443/RC0603FR-0710KL-Yageo-datasheet-8324193.pdf?src-supplier=Future+Electronics; ComponentLink16URL: https://datasheet.octopart.com:443/RC0603FR-0710KL-Yageo-datasheet-8324193.pdf?src-supplier=Future+Electronics; ComponentLink17Description: https://datasheet.octopart.com:443/RC0603FR-0710KL-Yageo-datasheet-12596169.pdf?src-supplier=Newark; ComponentLink17URL: https://datasheet.octopart.com:443/RC0603FR-0710KL-Yageo-datasheet-12596169.pdf?src-supplier=Newark; ComponentLink18Description: https://datasheet.octopart.com:443/RC0603FR-0710KL-Yageo-datasheet-503470.pdf?src-supplier=Newark; ComponentLink18URL: https://datasheet.octopart.com:443/RC0603FR-0710KL-Yageo-datasheet-503470.pdf?src-supplier=Newark; ComponentLink19Description: https://datasheet.octopart.com:443/RC0603FR-0710KL-Yageo-datasheet-34211833.pdf?src-supplier=Newark; ComponentLink19URL: https://datasheet.octopart.com:443/RC0603FR-0710KL-Yageo-datasheet-34211833.pdf?src-supplier=Newark; ComponentLink20Description: https://datasheet.octopart.com:443/RC0603FR-0710KL-Yageo-datasheet-79472498.pdf?src-supplier=Megastar; ComponentLink20URL: https://datasheet.octopart.com:443/RC0603FR-0710KL-Yageo-datasheet-79472498.pdf?src-supplier=Megastar; ComponentLink21Description: https://datasheet.octopart.com/RC0603FR-0710KL-Yageo-datasheet-73766026.pdf; ComponentLink21URL: https://datasheet.octopart.com/RC0603FR-0710KL-Yageo-datasheet-73766026.pdf; ComponentLink22Description: https://datasheet.octopart.com:443/RC0603FR-0710KL-Yageo-datasheet-118562.pdf?src-supplier=DigiKey; ComponentLink22URL: https://datasheet.octopart.com:443/RC0603FR-0710KL-Yageo-datasheet-118562.pdf?src-supplier=DigiKey; ComponentLink23Description: http://sigma.octopart.com:80/conflict_mineral_statement/Yageo-company-3.pdf?src-supplier=Compliance+Statements; ComponentLink23URL: http://sigma.octopart.com:80/conflict_mineral_statement/Yageo-company-3.pdf?src-supplier=Compliance+Statements; ComponentLink24Description: http://sigma.octopart.com:80/rohs_statement/Yageo-company-2.pdf?src-supplier=Compliance+Statements; ComponentLink24URL: http://sigma.octopart.com:80/rohs_statement/Yageo-company-2.pdf?src-supplier=Compliance+Statements; Composition: Thick Film; Contact Plating: Tin; Depth: 800µm; Height: 450µm; Lead Free: Lead Free; Lead Pitch: 300µm; Length: 1.6mm; Library Name: Standard.SchLib; Lifecycle Status: Production; Max Operating Temperature: 155°C; Military Standard: Not; Min Operating Temperature: -55°C; Mounting Technology: Surface Mount; Number of Terminals: 2; Packaging: Tape & Reel; Pins: 2; Power Rating: 100mW; Pricing: 5000=0.00136, 10000=0.00132, 20000=0.00129, 40000=0.00126, 80000=0.00123 (USD); Radiation Hardening: No; REACH SVHC: Yes; RoHS: Compliant; Schedule B: 8533210045, 8533210045|8533210045|8533210045|8533210045|8533210045|8533210045|8533210045|8533210045|8533210045|8533210045|8533210045|8533210045|8533210045|8533210045|8533210045|8533210045|8533210045|8533210045|8533210045|8533210045|8533210045|8533210045|8533210045|85; Stock: 2520000; Supplier 1: Avnet; Supplier Part Number 1: RC0603FR-0710KL; Termination: SMD/SMT; Tolerance: 1%; Value: 10k; Weight: 2.012816mg; Width: 800µm; Working Voltage: 75V
R5 R6 R18 R19 = RES: General Purpose Chip Resistors RC0603 Rohs Compliant | Res SMD 1.8K Ohm 1% 1/10W 0603; Manufacturer: Yageo Group; Manufacturer Part Number: RC0603FR-071K8L; Max Power Dissipation: 100mW; Temperature Coefficient: 100ppm/°C; Voltage Rating: 75V; Voltage Rating (AC): 50V; Case Code (Imperial): 0603; Case/Package: 0603; Category: Chip SMD Resistors; China RoHS: Non-Compliant; Component Kind: Standard; ComponentLink1Description: https://datasheet.octopart.com:443/RC0603FR-071K8L-Yageo-datasheet-175887523.pdf?src-supplier=IHS; ComponentLink1URL: https://datasheet.octopart.com:443/RC0603FR-071K8L-Yageo-datasheet-175887523.pdf?src-supplier=IHS; ComponentLink2Description: https://datasheet.octopart.com:443/RC0603FR-071K8L-Yageo-datasheet-141265859.pdf?src-supplier=Upverter; ComponentLink2URL: https://datasheet.octopart.com:443/RC0603FR-071K8L-Yageo-datasheet-141265859.pdf?src-supplier=Upverter; ComponentLink3Description: https://datasheet.octopart.com:443/RC0603FR-071K8L-Yageo-datasheet-103092701.pdf?src-supplier=Upverter; ComponentLink3URL: https://datasheet.octopart.com:443/RC0603FR-071K8L-Yageo-datasheet-103092701.pdf?src-supplier=Upverter; ComponentLink4Description: https://datasheet.octopart.com:443/RC0603FR-071K8L-Yageo-Group-datasheet-190305687.pdf?src-supplier=Future+Electronics; ComponentLink4URL: https://datasheet.octopart.com:443/RC0603FR-071K8L-Yageo-Group-datasheet-190305687.pdf?src-supplier=Future+Electronics; ComponentLink5Description: https://datasheet.octopart.com:443/RC0603FR-071K8L-Yageo-datasheet-164759695.pdf?src-supplier=Future+Electronics; ComponentLink5URL: https://datasheet.octopart.com:443/RC0603FR-071K8L-Yageo-datasheet-164759695.pdf?src-supplier=Future+Electronics; ComponentLink6Description: https://datasheet.octopart.com:443/RC0603FR-071K8L-Yageo-datasheet-137700087.pdf?src-supplier=Future+Electronics; ComponentLink6URL: https://datasheet.octopart.com:443/RC0603FR-071K8L-Yageo-datasheet-137700087.pdf?src-supplier=Future+Electronics; ComponentLink7Description: https://datasheet.octopart.com:443/RC0603FR-071K8L-Yageo-datasheet-27584510.pdf?src-supplier=Future+Electronics; ComponentLink7URL: https://datasheet.octopart.com:443/RC0603FR-071K8L-Yageo-datasheet-27584510.pdf?src-supplier=Future+Electronics; ComponentLink8Description: https://datasheet.octopart.com:443/RC0603FR-071K8L-Yageo-datasheet-15599030.pdf?src-supplier=Future+Electronics; ComponentLink8URL: https://datasheet.octopart.com:443/RC0603FR-071K8L-Yageo-datasheet-15599030.pdf?src-supplier=Future+Electronics; ComponentLink9Description: https://datasheet.octopart.com:443/RC0603FR-071K8L-Yageo-datasheet-12596169.pdf?src-supplier=Future+Electronics; ComponentLink9URL: https://datasheet.octopart.com:443/RC0603FR-071K8L-Yageo-datasheet-12596169.pdf?src-supplier=Future+Electronics; ComponentLink10Description: https://datasheet.octopart.com:443/RC0603FR-071K8L-Yageo-datasheet-8324193.pdf?src-supplier=Future+Electronics; ComponentLink10URL: https://datasheet.octopart.com:443/RC0603FR-071K8L-Yageo-datasheet-8324193.pdf?src-supplier=Future+Electronics; ComponentLink11Description: https://datasheet.octopart.com:443/RC0603FR-071K8L-Yageo-datasheet-8328172.pdf?src-supplier=Newark; ComponentLink11URL: https://datasheet.octopart.com:443/RC0603FR-071K8L-Yageo-datasheet-8328172.pdf?src-supplier=Newark; ComponentLink12Description: https://datasheet.octopart.com:443/RC0603FR-071K8L-Yageo-datasheet-62067350.pdf?src-supplier=Newark; ComponentLink12URL: https://datasheet.octopart.com:443/RC0603FR-071K8L-Yageo-datasheet-62067350.pdf?src-supplier=Newark; ComponentLink13Description: https://datasheet.octopart.com:443/RC0603FR-071K8L-Yageo-Group-datasheet-176638170.pdf?src-supplier=Newark; ComponentLink13URL: https://datasheet.octopart.com:443/RC0603FR-071K8L-Yageo-Group-datasheet-176638170.pdf?src-supplier=Newark; ComponentLink14Description: https://datasheet.octopart.com:443/RC0603FR-071K8L-Yageo-datasheet-34211833.pdf?src-supplier=Farnell; ComponentLink14URL: https://datasheet.octopart.com:443/RC0603FR-071K8L-Yageo-datasheet-34211833.pdf?src-supplier=Farnell; ComponentLink15Description: https://datasheet.octopart.com:443/RC0603FR-071K8L-Yageo-datasheet-148775077.pdf?src-supplier=TME; ComponentLink15URL: https://datasheet.octopart.com:443/RC0603FR-071K8L-Yageo-datasheet-148775077.pdf?src-supplier=TME; ComponentLink16Description: https://datasheet.octopart.com:443/RC0603FR-071K8L-Yageo-datasheet-79472498.pdf?src-supplier=Megastar; ComponentLink16URL: https://datasheet.octopart.com:443/RC0603FR-071K8L-Yageo-datasheet-79472498.pdf?src-supplier=Megastar; ComponentLink17Description: https://datasheet.octopart.com/RC0603FR-071K8L-Yageo-datasheet-73766026.pdf; ComponentLink17URL: https://datasheet.octopart.com/RC0603FR-071K8L-Yageo-datasheet-73766026.pdf; ComponentLink18Description: https://datasheet.octopart.com:443/RC0603FR-071K8L-Yageo-datasheet-30459151.pdf?src-supplier=Seeed+Studio; ComponentLink18URL: https://datasheet.octopart.com:443/RC0603FR-071K8L-Yageo-datasheet-30459151.pdf?src-supplier=Seeed+Studio; ComponentLink19Description: https://datasheet.octopart.com:443/RC0603FR-071K8L-Yageo-datasheet-118562.pdf?src-supplier=DigiKey; ComponentLink19URL: https://datasheet.octopart.com:443/RC0603FR-071K8L-Yageo-datasheet-118562.pdf?src-supplier=DigiKey; ComponentLink20Description: http://sigma.octopart.com:80/conflict_mineral_statement/Yageo-company-3.pdf?src-supplier=Compliance+Statements; ComponentLink20URL: http://sigma.octopart.com:80/conflict_mineral_statement/Yageo-company-3.pdf?src-supplier=Compliance+Statements; ComponentLink21Description: http://sigma.octopart.com:80/rohs_statement/Yageo-company-2.pdf?src-supplier=Compliance+Statements; ComponentLink21URL: http://sigma.octopart.com:80/rohs_statement/Yageo-company-2.pdf?src-supplier=Compliance+Statements; Composition: Thick Film; Contact Plating: Tin; Depth: 800µm; Features: Moisture Resistant; Height: 450µm; Lead Free: Lead Free; Length: 1.6mm; Library Name: Standard.SchLib; Lifecycle Status: Production; Max Operating Temperature: 155°C; Military Standard: Not; Min Operating Temperature: -55°C; Mounting Technology: Surface Mount; Number of Terminals: 2; Number of Terminations: 2; Packaging: Cut Tape; Pins: 2; Power Rating: 100mW; Pricing: 5000=0.00137, 10000=0.00137, 20000=0.00136, 40000=0.00135, 80000=0.00134 (USD); Radiation Hardening: No; REACH SVHC: Yes; RoHS: Compliant; Schedule B: 8533210045, 8533210045|8533210045, 8533210045|8533210045|8533210045, 8533210045|8533210045|8533210045|8533210045; Stock: 485000; Supplier 1: Avnet; Supplier Part Number 1: RC0603FR-071K8L; Termination: SMD/SMT; Tolerance: 1%; Value: 1k8; Voltage Rating (DC): 50V; Width: 800µm; Working Voltage: 75V
R7 R8 R20 R21 = POT: Cermet Not Linear TRIMMER Trimmer Potentiometer ±10% 500mW 70C 300V; Manufacturer: Bourns; Manufacturer Part Number: 3296W-1-203LF; Lead Diameter: 510µm; Lead Length: 6.4mm; Number of Turns: 25; Temperature Coefficient: 100ppm/°C; Value: 20kΩ; Category: Variable Resistors and Potentiometers; China RoHS: Non-Compliant; Color: Blue; Component Kind: Standard; ComponentLink1Description: https://datasheet.octopart.com:443/3296W-1-203LF-Bourns-datasheet-154325949.pdf?src-supplier=Newark; ComponentLink1URL: https://datasheet.octopart.com:443/3296W-1-203LF-Bourns-datasheet-154325949.pdf?src-supplier=Newark; ComponentLink2Description: https://datasheet.octopart.com:443/3296W-1-203LF-Bourns-datasheet-62345832.pdf?src-supplier=Newark; ComponentLink2URL: https://datasheet.octopart.com:443/3296W-1-203LF-Bourns-datasheet-62345832.pdf?src-supplier=Newark; ComponentLink3Description: https://datasheet.octopart.com:443/3296W-1-203LF-Bourns-datasheet-8754025.pdf?src-supplier=Burklin+Elektronik; ComponentLink3URL: https://datasheet.octopart.com:443/3296W-1-203LF-Bourns-datasheet-8754025.pdf?src-supplier=Burklin+Elektronik; ComponentLink4Description: https://datasheet.octopart.com:443/3296W-1-203LF-Bourns-datasheet-170576125.pdf?src-supplier=Burklin+Elektronik; ComponentLink4URL: https://datasheet.octopart.com:443/3296W-1-203LF-Bourns-datasheet-170576125.pdf?src-supplier=Burklin+Elektronik; ComponentLink5Description: https://datasheet.octopart.com:443/3296W-1-203LF-Bourns-datasheet-9257.pdf?src-supplier=_legacy+Avnet; ComponentLink5URL: https://datasheet.octopart.com:443/3296W-1-203LF-Bourns-datasheet-9257.pdf?src-supplier=_legacy+Avnet; ComponentLink6Description: https://datasheet.octopart.com:443/3296W-1-203LF-Bourns-datasheet-8359740.pdf?src-supplier=_legacy+Avnet; ComponentLink6URL: https://datasheet.octopart.com:443/3296W-1-203LF-Bourns-datasheet-8359740.pdf?src-supplier=_legacy+Avnet; ComponentLink7Description: https://datasheet.octopart.com:443/3296W-1-203LF-Bourns-datasheet-25360155.pdf?src-supplier=Future+Electronics; ComponentLink7URL: https://datasheet.octopart.com:443/3296W-1-203LF-Bourns-datasheet-25360155.pdf?src-supplier=Future+Electronics; ComponentLink8Description: https://datasheet.octopart.com:443/3296W-1-203LF-Bourns-datasheet-154729564.pdf?src-supplier=Electro+Sonic; ComponentLink8URL: https://datasheet.octopart.com:443/3296W-1-203LF-Bourns-datasheet-154729564.pdf?src-supplier=Electro+Sonic; ComponentLink9Description: https://datasheet.octopart.com:443/3296W-1-203LF-Bourns-datasheet-8458046.pdf?src-supplier=Farnell; ComponentLink9URL: https://datasheet.octopart.com:443/3296W-1-203LF-Bourns-datasheet-8458046.pdf?src-supplier=Farnell; ComponentLink10Description: https://datasheet.octopart.com:443/3296W-1-203LF-Bourns-datasheet-6152.pdf?src-supplier=Farnell; ComponentLink10URL: https://datasheet.octopart.com:443/3296W-1-203LF-Bourns-datasheet-6152.pdf?src-supplier=Farnell; ComponentLink11Description: https://datasheet.octopart.com:443/3296W-1-203LF-Bourns-datasheet-528316.pdf?src-supplier=Farnell; ComponentLink11URL: https://datasheet.octopart.com:443/3296W-1-203LF-Bourns-datasheet-528316.pdf?src-supplier=Farnell; ComponentLink12Description: https://datasheet.octopart.com:443/3296W-1-203LF-Bourns-datasheet-14175298.pdf?src-supplier=iiiC; ComponentLink12URL: https://datasheet.octopart.com:443/3296W-1-203LF-Bourns-datasheet-14175298.pdf?src-supplier=iiiC; ComponentLink13Description: https://datasheet.octopart.com:443/3296W-1-203LF-Bourns-datasheet-7086880.pdf?src-supplier=Jameco; ComponentLink13URL: https://datasheet.octopart.com:443/3296W-1-203LF-Bourns-datasheet-7086880.pdf?src-supplier=Jameco; ComponentLink14Description: http://sigma.octopart.com:80/conflict_mineral_statement/Bourns-company-36.pdf?src-supplier=Compliance+Statements; ComponentLink14URL: http://sigma.octopart.com:80/conflict_mineral_statement/Bourns-company-36.pdf?src-supplier=Compliance+Statements; Composition: Cermet; Contact Plating: Tin; Country of Origin: Costa Rica; Depth: 4.83mm; Export Control Classification Number (ECCN) Code: EAR99; Harmonized Tariff Schedule (HTS) Code: 8533.40.80.50; Height: 10.03mm; Introduction Date: 2004-09-13; Lead Free: Lead Free; Length: 9.53mm; Library Name: Standard.SchLib; Lifecycle Status: Production; Max Operating Temperature: 125°C; Max Power Dissipation: 500mW; Military Standard: Not; Min Operating Temperature: -55°C; Mounting Technology: PCB, Panel, Through Hole; Number of Terminals: 3; Power Rating: 500mW; Pricing: 1=2.3, 10=1.99, 25=1.79, 50=1.75, 100=1.7, 500=1.53, 1000=1.46 (USD); Radiation Hardening: No; REACH SVHC: No; RoHS: Compliant; Schedule B: 8533400080, 8533400080|8533400080, 8533400080|8533400080|8533400080, 8533400080|8533400080|8533400080|8533400080; Stock: 1203; Supplier 1: Avnet; Supplier Part Number 1: 62J1439; Taper: Linear; Termination: Pin; Tolerance: 10%; Voltage Rating (DC): 300V; Weight: 850.485694mg; Width: 4.83mm; Working Voltage: 300V
